# Altium schematic sheet Status_Net_LEDs.SchDoc
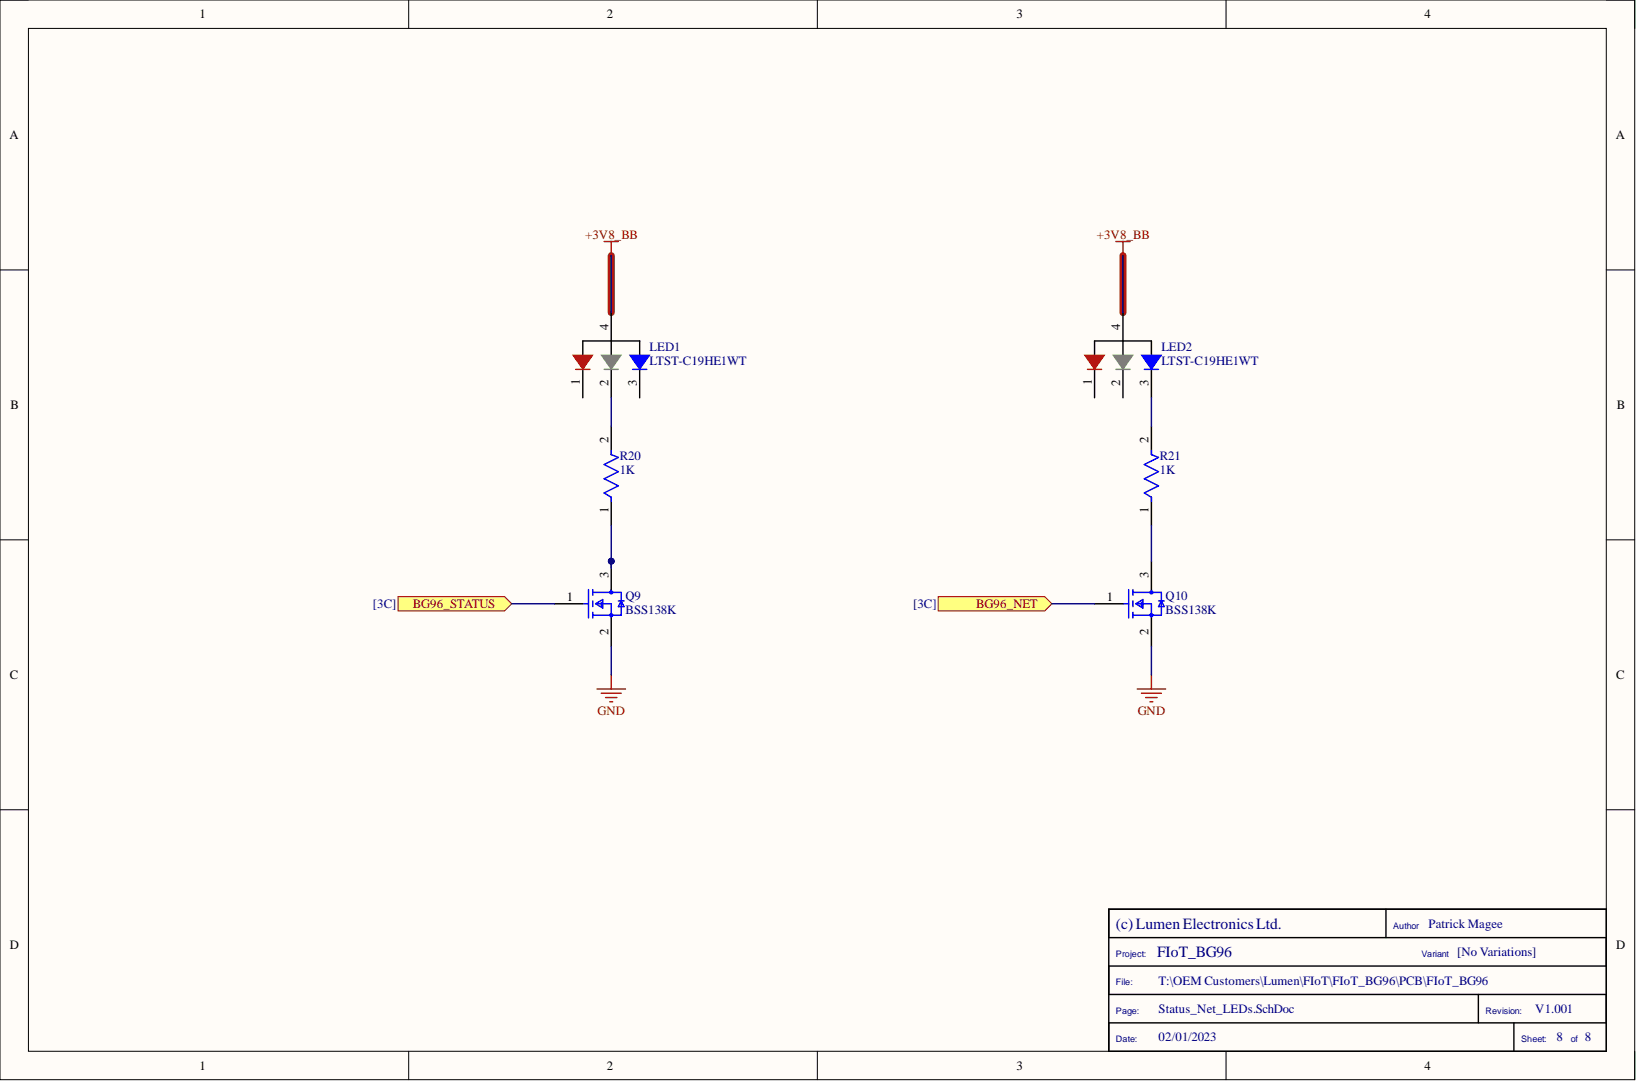
[diagram p0: Status_Net_LEDs.SchDoc]

## Parts
LED1  LTST-C19HE1WT  [LED_LTST-C19HE1WT]
LED2  LTST-C19HE1WT  [LED_LTST-C19HE1WT]
Q9  BSS138K  [FP-318-08-IPC_B]
Q10  BSS138K  [FP-318-08-IPC_B]
R20  Resistance: 1K  [FP-CRCW0402-e3-MFG]  (hidden comment: 0402WGF1001TCE)
R21  Resistance: 1K  [FP-CRCW0402-e3-MFG]  (hidden comment: 0402WGF1001TCE)

## Ports
BG96_NET, BG96_STATUS

## Nets
+3V8_BB: LED1.4 LED2.4
GND: Q9.2 Q10.2
BG96_NET: Q10.1 ; port BG96_NET
BG96_STATUS: Q9.1 ; port BG96_STATUS
NetLED1_2: LED1.2 R20.2
NetLED2_3: LED2.3 R21.2
NetQ9_3: Q9.3 R20.1
NetQ10_3: Q10.3 R21.1

Not connected: LED1 1 3; LED2 1 2

## Library data of the parts
LED1 LED2 = LTST-C19HE1WT: Red, Green, Blue _RGB_ 624nm Red, 525nm Green, 470nm Blue LED Indication - Discrete 2.1V Red, 3.35V Green, 3.35V Blue 0606 _1616 Metric_; MF: Lite-On Inc.; Availability: Unavailable; Component Kind: Standard; Library Name: FIoT_BG96.SCHLIB; MP: LTST-C19HE1WT; Package: 0606 Lite-On; Price: None; SnapEDA_Link: https://www.snapeda.com/parts/LTST-C19HE1WT/Lite-On%20Inc./view-part/423425/?ref=snap; Supplier 1: Digi-Key; Supplier 2: Mouser; Supplier Part Number 1: 160-2162-1-ND; Supplier Part Number 2: 859-LTST-C19HE1WT
Q9 Q10 = BSS138K: MOSFET N-CH 50V 220MA SOT-23; Manufacturer: ON Semiconductor / Fairchild; Manufacturer Part Number: BSS138; Drain to Source Resistance: 3.5R; Element Configuration: Single; Fall Time: 9ns; Gate to Source Voltage (Vgs): 20V; Input Capacitance: 27pF; Max Power Dissipation: 360mW; Nominal Vgs: 1.3V; Number of Elements: 1; On-State Resistance: 6R; Turn-Off Delay Time: 20ns; Turn-On Delay Time: 2.5ns; Case/Package: SOT-23; Component Kind: Standard; Contact Plating: Tin; Continuous Drain Current (ID): 220mA; Current Rating: 220mA; Drain to Source Breakdown Voltage: 50V; Drain to Source Voltage (Vdss): 50V; Dual Supply Voltage: 50V; Height: 0.93mm; JLC Footprint: SOT-23-3; JLCPCB Part #: C52895; Lead Free: Lead Free; Length: 2.92mm; Library Name: FIoT_BG96.SCHLIB; Max Operating Temperature: 150°C; MFG Name: ON Semiconductor / Fairchild; MFG Part Number: BSS138; Min Operating Temperature: -55°C; Mount: Surface Mount; Number of Pins: 3; Package Quantity: 1; Packaging: Tape and Reel; Power Dissipation: 360mW; Radiation Hardening: No; Rds On Max: 3.5R; REACH SVHC: No SVHC; Rise Time: 9ns; Supplier 1: Digi-Key; Supplier 2: Farnell; Supplier 3: Mouser; Supplier Part Number 1: BSS138K-13DICT-ND; Supplier Part Number 2: 3943470; Supplier Part Number 3: 621-BSS138K-13; Termination: SMD/SMT; Threshold Voltage: 1.3V; Voltage Rating (DC): 50V; Weight: 0.03g; Width: 1.3mm
R20 R21 = 0402WGF1001TCE: Res Thick Film 0402 1K Ohm 1% 0.063W; Manufacturer: Vishay Dale; Manufacturer Part Number: CRCW04021K00FKED; Max Power Dissipation: 63mW; Temperature Coefficient: 100ppm/°C; Voltage Rating: 50V; Case Code (Imperial): 0402; Case Code (Metric): 1005; Case/Package: 0402; Component Kind: Standard; Composition: Thick Film; Contact Plating: Tin; Depth: 0.5mm; Features: Automotive AEC-Q200; Height: 0.35mm; JLC Footprint: R0402; JLCPCB Part #: Not available with JLC; Lead Free: Lead Free; Length: 1mm; Library Name: FIoT_BG96.SCHLIB; Max Operating Temperature: 155°C; MFG Name: Vishay Dale; MFG Part Number: CRCW04021K00FKED; Military Standard: Not; Min Operating Temperature: -55°C; Mount: Surface Mount; Number of Pins: 2; Number of Terminations: 2; Package Quantity: 10; Packaging: Tape and Reel; Power Rating: 63mW; Radiation Hardening: No; Supplier 1: Mouser; Supplier Part Number 1: 303-0402WGF1001TCE; Termination: SMD/SMT; Tolerance: 1%; Voltage Rating (DC): 50V; Weight: 2.3E-05oz; Width: 0.5mm
